# Altium schematic sheet Sheet2.SchDoc(Sheet2)
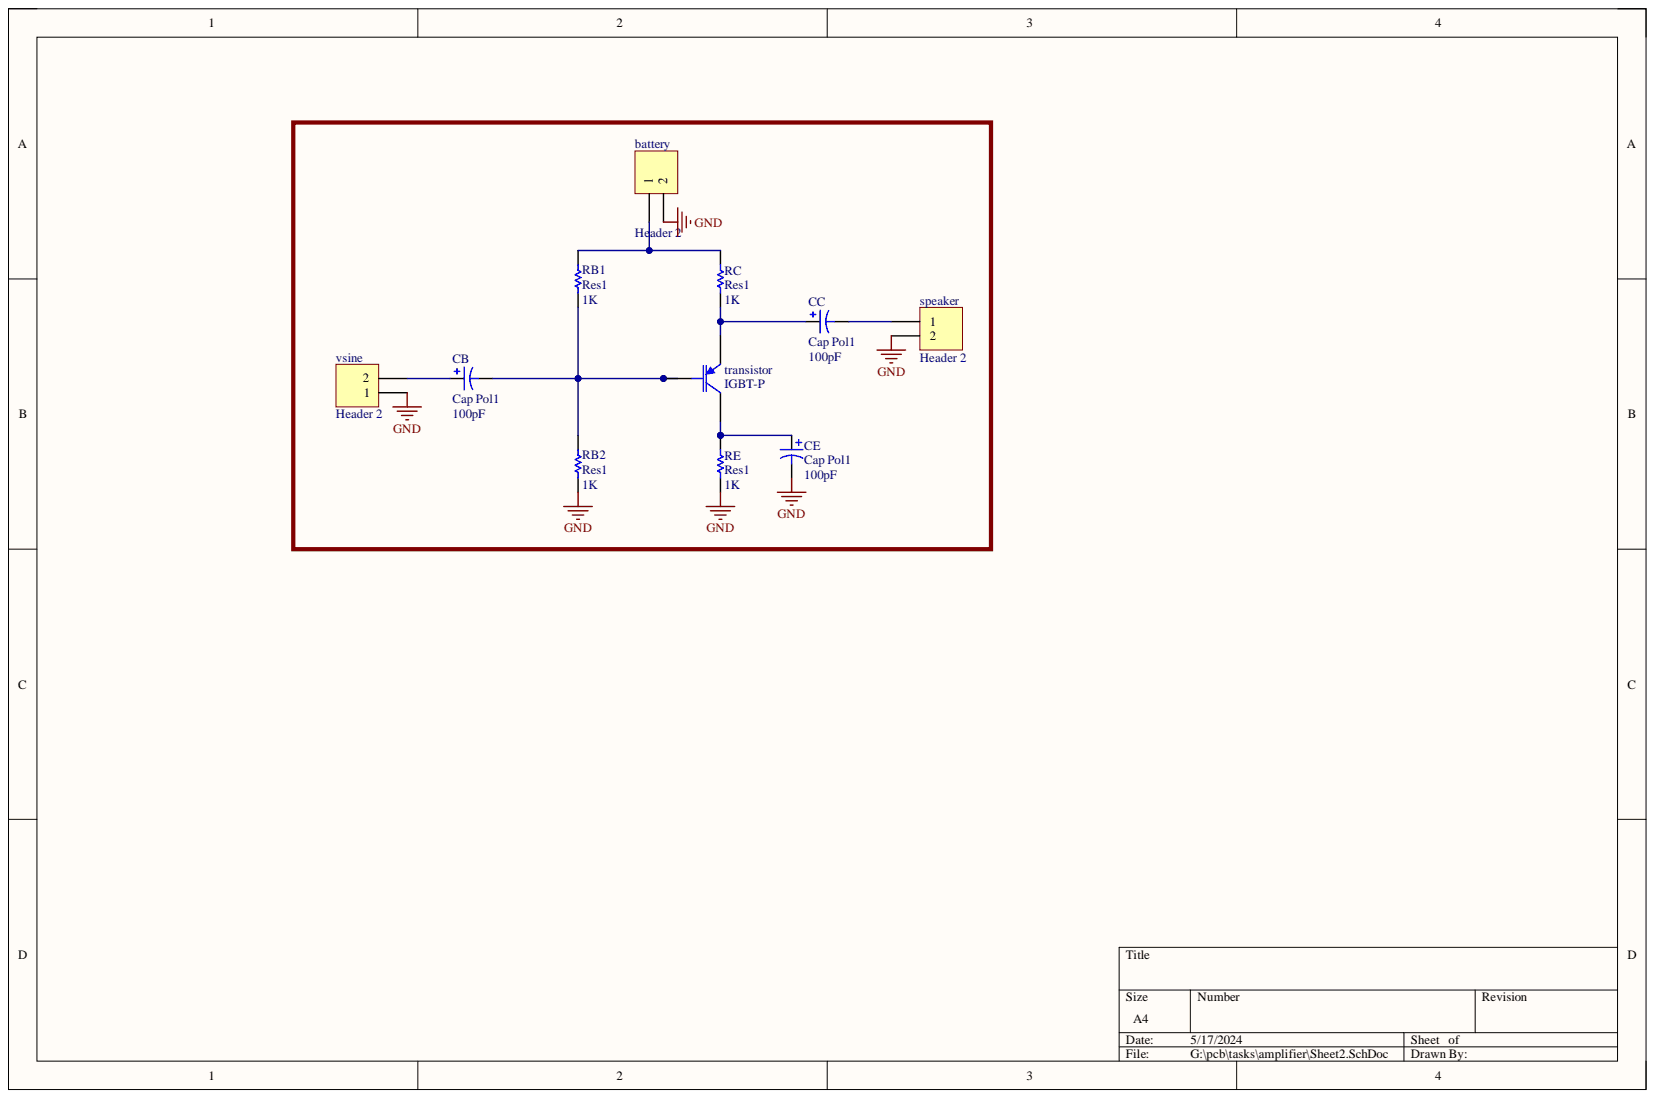
[diagram p0: Sheet2.SchDoc]

## Parts
battery  Header 2  [HDR1X2]
CB  Cap Pol1  value 100pF  [RB7.6-15]
CC  Cap Pol1  value 100pF  [RB7.6-15]
CE  Cap Pol1  value 100pF  [RB7.6-15]
RB1  Res1  value 1K  [AXIAL-0.3]
RB2  Res1  value 1K  [AXIAL-0.3]
RC  Res1  value 1K  [AXIAL-0.3]
RE  Res1  value 1K  [AXIAL-0.3]
speaker  Header 2  [HDR1X2]
transistor  IGBT-P  [TO-264-AA]
vsine  Header 2  [HDR1X2]

## Nets
GND: battery.2 CE.2 RB2.1 RE.1 speaker.2 vsine.1
Netbattery_1: battery.1 RB1.2 RC.2
NetCB_1: CB.1 vsine.2
NetCB_2: CB.2 RB1.1 RB2.2 transistor.2
NetCC_1: CC.1 RC.1 transistor.3
NetCC_2: CC.2 speaker.1
NetCE_1: CE.1 RE.2 transistor.1

## Library data of the parts
battery speaker vsine = Header 2: Header, 2-Pin; Component Kind: Standard; LatestRevisionDate: 17-Jul-2002; LatestRevisionNote: Re-released for DXP Platform.; Library Name: Miscellaneous Connectors.IntLib; Signal Integrity: Connector; Publisher: Altium Limited
CB CC CE = Cap Pol1: Polarized Capacitor (Radial); Component Kind: Standard; LatestRevisionDate: 17-Jul-2002; LatestRevisionNote: Re-released for DXP Platform.; Library Name: Miscellaneous Devices.IntLib; PackageDescription: Polarized Capacitor (Radial); 2 Leads; PackageReference: RB7.6-15; Published: 8-Jun-2000; Signal Integrity: Cap Pol; Simulation: CAP; Publisher: Altium Limited
RB1 RB2 RC RE = Res1: Resistor; Component Kind: Standard; LatestRevisionDate: 17-Jul-2002; LatestRevisionNote: Re-released for DXP Platform.; Library Name: Miscellaneous Devices.IntLib; PackageDescription: Axial Device, Thru-Hole; 2 Leads; 0.3 in Pin Spacing; PackageReference: AXIAL-0.3; Published: 8-Jun-2000; Signal Integrity: Res; Simulation: RESISTOR; Publisher: Altium Limited
transistor = IGBT-P: PNP Bipolar Junction Transistor; Code_JEDEC: TO-264-AA; Component Kind: Standard; LatestRevisionDate: 17-Jul-2002; LatestRevisionNote: Re-released for DXP Platform.; Library Name: Miscellaneous Devices.IntLib; PackageReference: TO-264-AA; Published: 8-Jun-2000; Simulation: PIGBT; Publisher: Altium Limited
